# Altium schematic sheet ESP32_S3.SchDoc
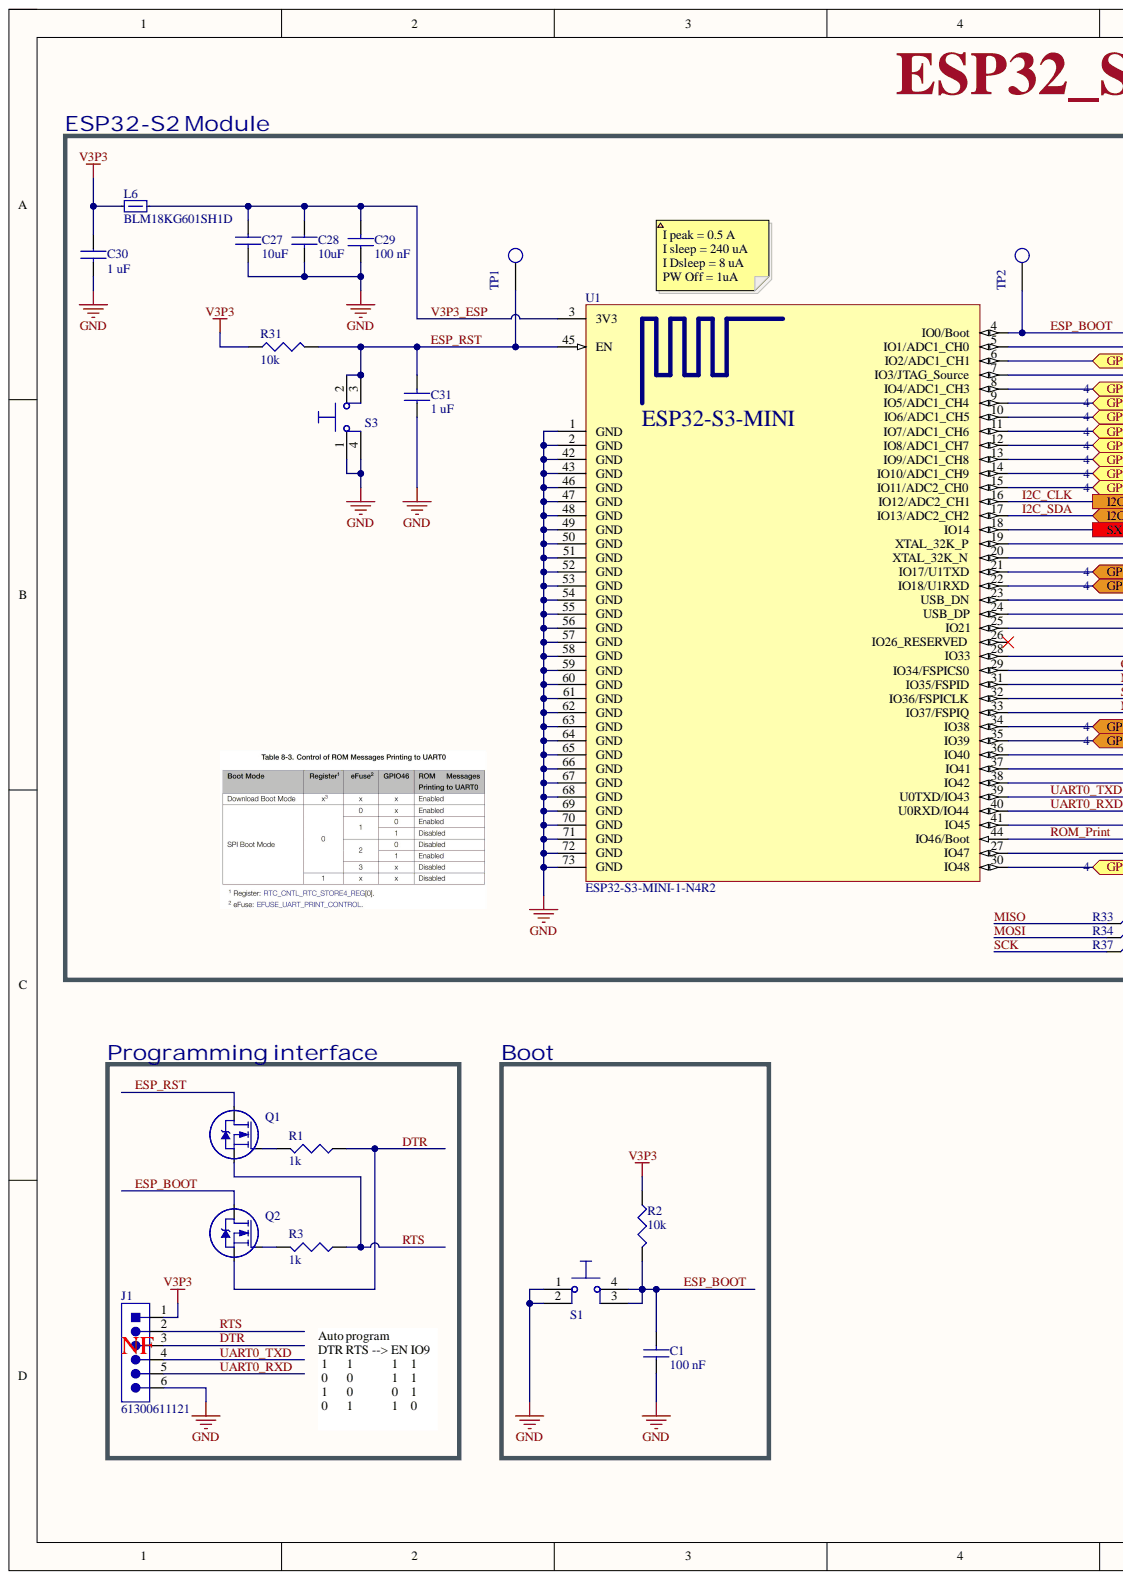
[diagram p0: ESP32_S3.SchDoc, left]
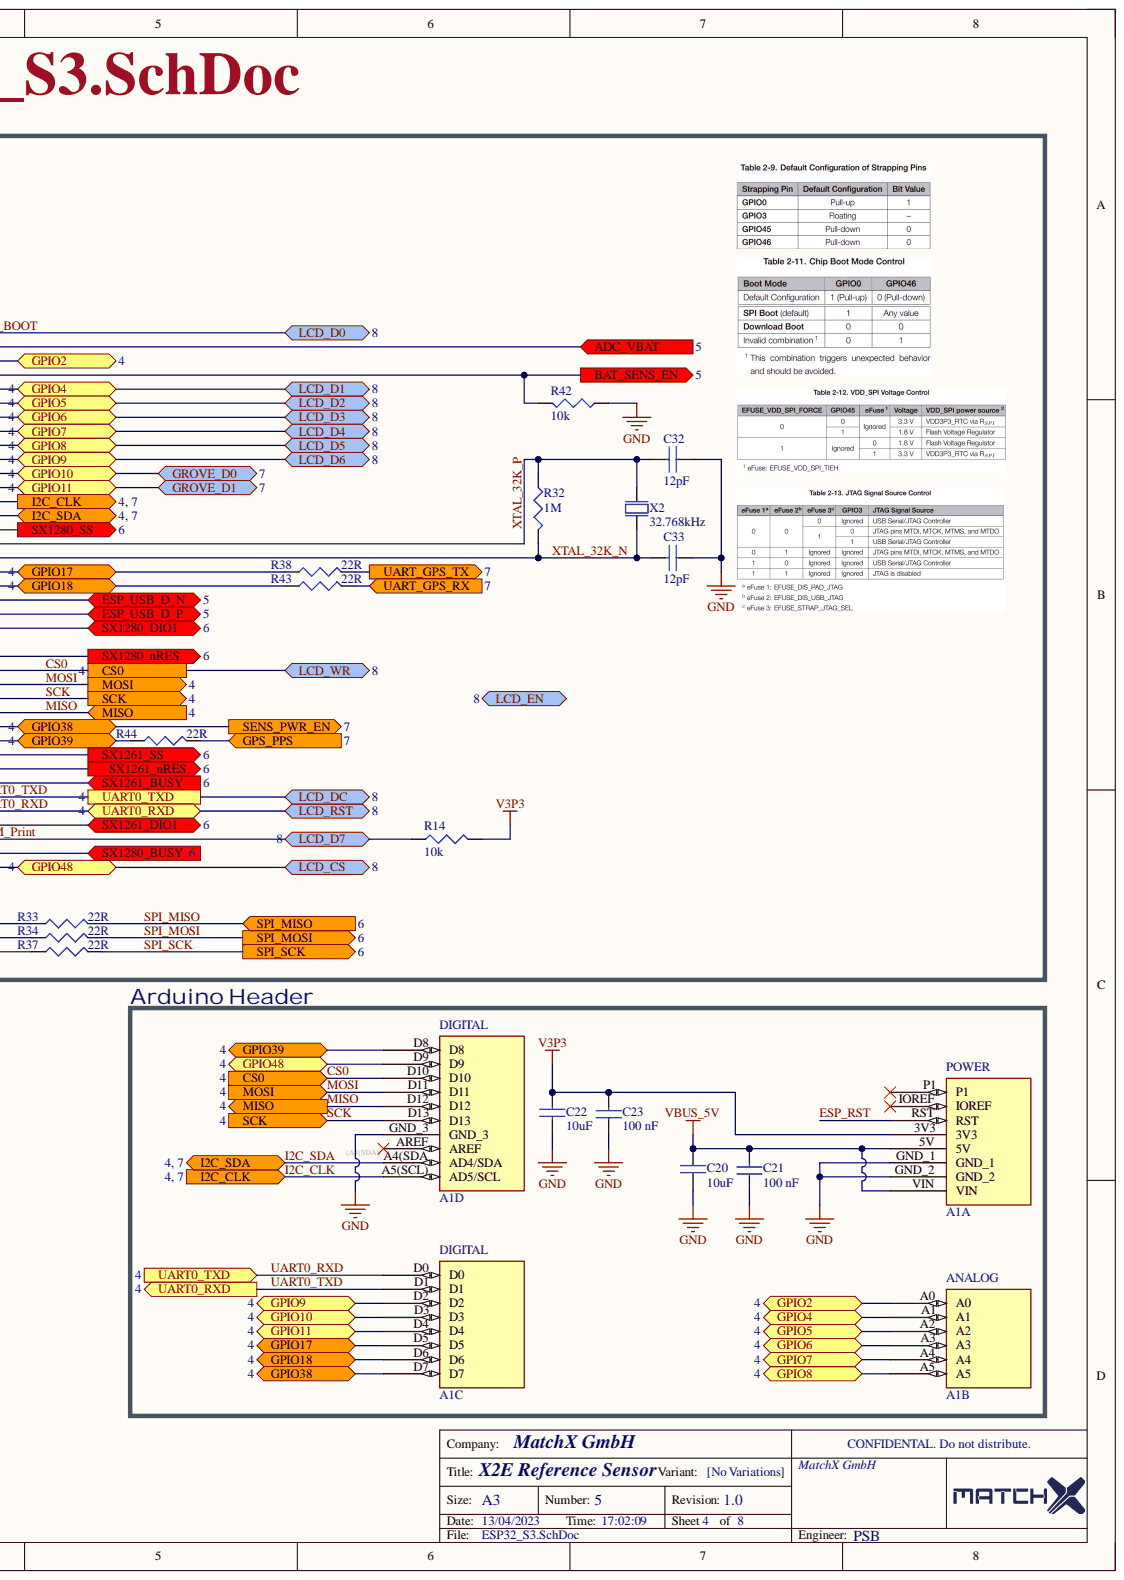
[diagram p1: ESP32_S3.SchDoc, right]

## Parts
A1  Arduino_Conn  [ARDUINO_TEMPLATE]  (value not drawn)  (parts A,B,C,D)
C1  100 nF  [C0603]
C20  10uF  [C0805]
C21  100 nF  [C0603]
C22  10uF  [C0805]
C23  100 nF  [C0603]
C27  10uF  [C0805]
C28  10uF  [C0805]
C29  100 nF  [C0603]
C30  1 uF  [C0603]
C31  1 uF  [C0603]
C32  12pF  [C0402]
C33  12pF  [C0402]
J1  61300611121  [WURTH_61300611121]
L6  BLM18KG601SH1D  [L0603]
Q1  DMN3023L-7  [SOT-23]  (value not drawn)
Q2  DMN3023L-7  [SOT-23]  (value not drawn)
R1  1k  [R0603]
R2  10k  [R0402]
R3  1k  [R0603]
R14  10k  [R0402]
R31  10k  [R0402]
R32  1M  [R0402]
R33  22R  [R0402]
R34  22R  [R0402]
R37  22R  [R0402]
R38  22R  [R0402]
R42  10k  [R0402]
R43  22R  [R0402]
R44  22R  [R0402]
S1  TS-1108A  [TS-1108A]  (value not drawn)
S3  TS-1108A  [TS-1108A]  (value not drawn)
TP1  TP  [TP_0R8]  (no BOM)
TP2  TP  [TP_0R8]  (no BOM)
U1  ESP32-S3-MINI-1-N4R2  [ESP32-S3-MINI-1-N4R2]
X2  32.768kHz  [XTAL_3215]

## Ports
ADC_VBAT, BAT_SENS_EN, CS0, ESP_USB_D_N, ESP_USB_D_P, GPIO2, GPIO4, GPIO5, GPIO6, GPIO7, GPIO8, GPIO9, GPIO10, GPIO11, GPIO17, GPIO18, GPIO38, GPIO39, GPIO48, GPS_PPS, GROVE_D0, GROVE_D1, I2C_CLK, I2C_SDA, LCD_CS, LCD_D0, LCD_D1, LCD_D2, LCD_D3, LCD_D4, LCD_D5, LCD_D6, LCD_D7, LCD_DC, LCD_EN, LCD_RST, LCD_WR, MISO, MOSI, SCK, SENS_PWR_EN, SPI_MISO, SPI_MOSI, SPI_SCK, SX1261_BUSY, SX1261_DIO1, SX1261_nRES, SX1261_SS, SX1280_BUSY, SX1280_DIO1, SX1280_nRES, SX1280_SS, UART0_RXD, UART0_TXD, UART_GPS_RX, UART_GPS_TX

## Nets
GND: A1.GND_1 A1.GND_2 A1.GND_3 C1.1 C20.2 C21.1 C22.2 C23.1 C27.2 C28.2 C29.1 C30.2 C31.1 C32.1 C33.1 J1.6 R42.1 S1.1 S1.2 S3.1 S3.4 U1.1(GND) U1.2(GND) U1.42(GND) U1.43(GND) U1.46(GND) U1.47(GND) U1.48(GND) U1.49(GND) U1.50(GND) U1.51(GND) U1.52(GND) U1.53(GND) U1.54(GND) U1.55(GND) U1.56(GND) U1.57(GND) U1.58(GND) U1.59(GND) U1.60(GND) U1.61(GND) U1.62(GND) U1.63(GND) U1.64(GND) U1.65(GND) U1.66(GND) U1.67(GND) U1.68(GND) U1.69(GND) U1.70(GND) U1.71(GND) U1.72(GND) U1.73(GND)
V3P3: A1.3V3 C22.1 C23.2 C30.1 J1.1 L6.1 R2.1 R14.2 R31.1
VBUS_5V: A1.5V A1.VIN C20.1 C21.2
ADC_VBAT: U1.5(IO1/ADC1_CH0) ; port ADC_VBAT
BAT_SENS_EN: R42.2 U1.7(IO3/JTAG_Source) ; port BAT_SENS_EN
CS0: A1.D10 U1.29(IO34/FSPICS0) ; port CS0, port LCD_WR
DTR: J1.3(NF) Q2.2 R1.2
ESP_BOOT: C1.2 Q2.3 R2.2 S1.3 S1.4 TP2.0 U1.4(IO0/Boot) ; port LCD_D0
ESP_RST: A1.RST C31.2 Q1.3 R31.2 S3.2 S3.3 TP1.0 U1.45(EN)
ESP_USB_D_N: U1.23(USB_DN) ; port ESP_USB_D_N
ESP_USB_D_P: U1.24(USB_DP) ; port ESP_USB_D_P
GPIO2: A1.A0 U1.6(IO2/ADC1_CH1) ; port GPIO2
GPIO4: A1.A1 U1.8(IO4/ADC1_CH3) ; port GPIO4, port LCD_D1
GPIO5: A1.A2 U1.9(IO5/ADC1_CH4) ; port GPIO5, port LCD_D2
GPIO6: A1.A3 U1.10(IO6/ADC1_CH5) ; port GPIO6, port LCD_D3
GPIO7: A1.A4 U1.11(IO7/ADC1_CH6) ; port GPIO7, port LCD_D4
GPIO8: A1.A5 U1.12(IO8/ADC1_CH7) ; port GPIO8, port LCD_D5
GPIO9: A1.D2 U1.13(IO9/ADC1_CH8) ; port GPIO9, port LCD_D6
GPIO10: A1.D3 U1.14(IO10/ADC1_CH9) ; port GPIO10, port GROVE_D0
GPIO11: A1.D4 U1.15(IO11/ADC2_CH0) ; port GPIO11, port GROVE_D1
GPIO17: A1.D5 R38.1 U1.21(IO17/U1TXD) ; port GPIO17
GPIO18: A1.D6 R43.1 U1.22(IO18/U1RXD) ; port GPIO18
GPIO38: A1.D7 U1.34(IO38) ; port GPIO38, port SENS_PWR_EN
GPIO39: A1.D8 R44.1 U1.35(IO39) ; port GPIO39
GPIO48: A1.D9 U1.30(IO48) ; port GPIO48, port LCD_CS
GPS_PPS: R44.2 ; port GPS_PPS
I2C_CLK: A1.A5(SCL) U1.16(IO12/ADC2_CH1) ; port I2C_CLK
I2C_SDA: A1.A4(SDA) U1.17(IO13/ADC2_CH2) ; port I2C_SDA
MISO: A1.D12 R33.1 U1.33(IO37/FSPIQ) ; port MISO
MOSI: A1.D11 R34.1 U1.31(IO35/FSPID) ; port MOSI
ROM_Print: R14.1 U1.44(IO46/Boot) ; port LCD_D7
RTS: J1.2 Q1.2 R3.2
SCK: A1.D13 R37.1 U1.32(IO36/FSPICLK) ; port SCK
SPI_MISO: R33.2 ; port SPI_MISO
SPI_MOSI: R34.2 ; port SPI_MOSI
SPI_SCK: R37.2 ; port SPI_SCK
SX1261_BUSY: U1.38(IO42) ; port SX1261_BUSY
SX1261_DIO1: U1.41(IO45) ; port SX1261_DIO1
SX1261_nRES: U1.37(IO41) ; port SX1261_nRES
SX1261_SS: U1.36(IO40) ; port SX1261_SS
SX1280_BUSY: U1.27(IO47) ; port SX1280_BUSY
SX1280_DIO1: U1.25(IO21) ; port SX1280_DIO1
SX1280_nRES: U1.28(IO33) ; port SX1280_nRES
SX1280_SS: U1.18(IO14) ; port SX1280_SS
UART0_RXD: A1.D0 A1.D1 J1.4 J1.5 U1.39(U0TXD/IO43) U1.40(U0RXD/IO44) ; port LCD_DC, port LCD_RST, port UART0_RXD, port UART0_TXD
UART_GPS_RX: R43.2 ; port UART_GPS_RX
UART_GPS_TX: R38.2 ; port UART_GPS_TX
V3P3_ESP: C27.1 C28.1 C29.2 L6.2 U1.3(3V3)
XTAL_32K_N: C33.2 R32.1 U1.20(XTAL_32K_N) X2.1
XTAL_32K_P: C32.2 R32.2 U1.19(XTAL_32K_P) X2.2
NetQ1_1: Q1.1 R1.1
NetQ2_1: Q2.1 R3.1
N00001: ; port LCD_EN

Not connected: A1 AREF IOREF P1; U1 26(IO26_RESERVED)

## Library data of the parts
A1 = Arduino_Conn: Arduino Template; MP: Arduino Uno Rev3; Availability: Not in stock; Check_prices: https://www.snapeda.com/parts/Arduino%20Uno%20Rev3/Arduino/view-part/?ref=eda; Component Kind: Standard; MF: Arduino; Package: Non-Standard Arduino; Price: None; SnapEDA_Link: https://www.snapeda.com/parts/Arduino%20Uno%20Rev3/Arduino/view-part/?ref=snap; Library Name: psblib.SCHLIB
C1 C21 C23 C29 = C0603-100nF_50V: CAP, CERM, 100 nF, 50V, +/- 10%, X7R, 0603; CompType: Capacitor; Category: Capacitors; Component Kind: Standard; MountType: SMT; Operating Temperature: -55°C ~ 125°C; Package / Case: 0603 (1608 Metric); RoHS: RoHS Compliant; Technology: Ceramic; Temperature Coefficient: X7R; Tolerance: 10%; Value: 100 nF; Voltage - Rated: 50V; Library Name: psblib.SCHLIB
C20 C22 C27 C28 = C0805-10uF_16V: CAP, CERM, 10uF, 16V, +/- 20%, X5R, 0805; CompType: Capacitor; Category: Capacitors; Component Kind: Standard; MountType: SMT; Operating Temperature: -55°C ~ 85°C; Package / Case: 0805 (2012 Metric); RoHS: RoHS Compliant; Technology: Ceramic; Temperature Coefficient: X5R; Tolerance: 20%; Value: 10uF; Voltage - Rated: 16 V; Library Name: psblib.SCHLIB
C30 C31 = C0603-1uF_25V: CAP, CERM, 1 uF, 25 V, +/- 10%, X7R, 0603; CompType: Capacitor; Voltage - Rated: 25 V; Category: Capacitors; Component Kind: Standard; MountType: SMT; Operating Temperature: -55°C ~ 125°C; Package / Case: 0603 (1608 Metric); RoHS: RoHS Compliant; Technology: Ceramic; Temperature Coefficient: X7R; Tolerance: 10%; Value: 1 uF; Library Name: psblib.SCHLIB
C32 C33 = C0402-12pF: CAP, CERM, 12pF, 16V, +/- 5%, C0G/NP0, 0402; CompType: Capacitor; Category: Capacitors; Component Kind: Standard; MountType: SMT; Operating Temperature: -55°C ~ 125°C; Package / Case: 0402; RoHS: RoHS Compliant; Technology: Ceramic; Temperature Coefficient: C0G/NP0; Tolerance: 5%; Value: 12pF; Voltage - Rated: 16 V; Library Name: psblib.SCHLIB
J1 = CON_61300611121: Header, 2.54 mm, 6x1, Gold, TH; Manufacturer: Wurth Electronics; Connector Type: Header, Unshrouded; Number of Positions: 6; Number of Positions Loaded: All; Pitch: 0.100" (2.54mm); Category: Connectors, Interconnects; Color: Black; Component Kind: Standard; ComponentLink1Description: http://www.farnell.com/cad/1737268.pdf; ComponentLink1URL: http://www.farnell.com/cad/1737268.pdf; Contact Finish: Gold; Contact Finish Thickness: -; Contact Mating Length: 0.236" (6.00mm); Contact Type: Male Pin; Family: Rectangular Connectors - Headers, Male Pins; Fastening Type: -; Features: -; Manufacturer Part Number: 61300611121; Mounting Type: Through Hole; Number of Rows: 1; Packaging: Bulk; Pricing 1: 1=0.25, 10=0.209, 25=0.174, 50=0.145, 100=0.132, 1000=0.12 (USD); Row Spacing: -; Series: WR-PHD; Stock 1: 0; Supplier 1: Digikey; Supplier Part Number 1: 732-5318-ND; Termination: Solder; Library Name: psblib.SCHLIB
L6 = BLM18KG601SH1D: Ferrite Beads 0603 600ohms 1.3A DCR=.15ohms; Manufacturer: Murata Electronics North America; DC Resistance (DCR) (Max): 150 mOhm; Filter Type: Power Line; Impedance @ Frequency: 600 Ohm @ 100MHz; Number of Lines: 1; Category: Filters; Component Kind: Standard; ComponentLink1Description: http://www.murata.com/en-global/products/productdata/8796737273886/QNFA9101.pdf; ComponentLink1URL: http://www.murata.com/en-global/products/productdata/8796737273886/QNFA9101.pdf; Current Rating (Max): 1.3A; Height (Max): 0.037" (0.95mm); Manufacturer Part Number: BLM18KG601SH1D; Mounting Type: Surface Mount; Operating Temperature: -55°C ~ 125°C; Package / Case: 0603 (1608 Metric); Packaging: Cut Tape (CT); Pricing 1: 1=0.11, 10=0.088, 50=0.058, 100=0.0502, 250=0.0396, 500=0.03344, 1000=0.02816 (USD); Ratings: AEC-Q200; RoHS: RoHS Compliant; Size / Dimension: 0.063" L x 0.032" W (1.60mm x 0.80mm); Stock 1: 5830; Supplier 1: Digi-Key; Supplier Part Number 1: 490-13259-1-ND; Library Name: psblib.SCHLIB
Q1 Q2 = NMOSFET_DMN3023L-7: MOSFETN-CH 30V 6.2A SOT23-3; Manufacturer: Diodes; .Checked PCB: OK M; Input Capacitance: 873pF; Max Power Dissipation: 900mW; Rds On Max: 25mR; Case/Package: TO-236-3; Category: MOSFETs; Component Kind: Standard; ComponentLink1Description: http://www.farnell.com/datasheets/2814401.pdf?src-supplier=Farnell; ComponentLink1URL: http://www.farnell.com/datasheets/2814401.pdf?src-supplier=Farnell; ComponentLink2Description: http://www.mouser.com/ds/2/115/DMN3023L-959533.pdf?src-supplier=Mouser; ComponentLink2URL: http://www.mouser.com/ds/2/115/DMN3023L-959533.pdf?src-supplier=Mouser; ComponentLink3Description: http://www.farnell.com/datasheets/2814401.pdf?src-supplier=Newark; ComponentLink3URL: http://www.farnell.com/datasheets/2814401.pdf?src-supplier=Newark; ComponentLink4Description: http://www.farnell.com/datasheets/2814401.pdf?src-supplier=Element14; ComponentLink4URL: http://www.farnell.com/datasheets/2814401.pdf?src-supplier=Element14; ComponentLink5Description: https://www.diodes.com/assets/Datasheets/DMN3023L.pdf?src-supplier=Digi-Key; ComponentLink5URL: https://www.diodes.com/assets/Datasheets/DMN3023L.pdf?src-supplier=Digi-Key; Continuous Drain Current (ID): 6.2A; Drain to Source Voltage (Vdss): 30V; Manufacturer Part Number: DMN3023L-7; Max Operating Temperature: 150°C; Min Operating Temperature: -55°C; Mount: Surface Mount; Packaging: Tape and Reel; Pricing 1: 1=0,41000, 10=0,33100, 100=0,22560, 500=0,16922, 1000=0,12692 (USD); RoHS: Yes; RoHS Compliant: Yes; Stock 1: 420813; Supplier 1: Digi-Key; Supplier Part Number 1: DMN3023L-7DICT-ND; .Checked SCH: OK M; Library Name: psblib.SCHLIB
R1 R3 = R0603-1k: RES, 1k, 1%, 0.063 W, 0603; .Checked PCB: OK RF; .Checked SCH: RF; Power (Watts): 0.063W, 1/16W; Category: Resistors; Component Kind: Standard; Composition: Thick Film; Features: -; Height: 0.016" (0.40mm); Number of Terminations: 2; Package / Case: 0603 [1608 Metric]; RoHS: RoHS Compliant; Size / Dimension: 0.063" L x 0.031" W (1.60mm x 0.80mm); Tolerance: ±1%; Value: 1k; Library Name: psblib.SCHLIB
R2 R14 R31 R42 = R0402-10k: RES, 10k, 1%, 1/16 W, 0402; .Checked PCB: OK RF; .Checked SCH: RF; Power (Watts): 0.063W, 1/16W; Category: Resistors; Component Kind: Standard; Composition: Thick Film; Features: -; Height: 0.016" (0.40mm); Number of Terminations: 2; Package / Case: 0402; RoHS: RoHS Compliant; Size / Dimension: 0.039" L x 0.020" W (1.00mm x 0.50mm); Tolerance: ±1%; Value: 10k; Library Name: psblib.SCHLIB
R32 = R0402-1M: RES, 1M, 1%, 1/16 W, 0402; .Checked PCB: OK RF; .Checked SCH: RF; Power (Watts): 0.063W, 1/16W; Category: Resistors; Component Kind: Standard; Composition: Thick Film; Features: -; Height: 0.016" (0.40mm); Number of Terminations: 2; Package / Case: 0402; RoHS: RoHS Compliant; Size / Dimension: 0.039" L x 0.020" W (1.00mm x 0.50mm); Tolerance: ±1%; Value: 1M; Library Name: psblib.SCHLIB
R33 R34 R37 R38 R43 R44 = R0402-22R: RES, 22R, 1%, 1/16 W, 0402; .Checked PCB: OK RF; .Checked SCH: RF; Power (Watts): 0.063W, 1/16W; Category: Resistors; Component Kind: Standard; Composition: Thick Film; Features: -; Height: 0.016" (0.40mm); Number of Terminations: 2; Package / Case: 0402; RoHS: RoHS Compliant; Size / Dimension: 0.039" L x 0.020" W (1.00mm x 0.50mm); Tolerance: ±1%; Value: 22R; Library Name: psblib.SCHLIB
S1 S3 = TS-1108A: Switch Tactile N.O. SPST Button 12VDC 50mA 5N SMD T/R; Manufacturer: ROPARTS; Component Kind: Standard; Manufacturer Part Number: TS-1108A; Pricing 1: 1=0,78000, 10=0,69500, 50=0,62700, 100=0,59800, 1000=0,48400, 10000=0,46500 (USD); RoHS: Yes; Stock 1: 3728; Library Name: psblib.SCHLIB
TP1 TP2 = TP: Test Point; Library Name: psblib.SCHLIB
U1 = ESP32-S3-MINI-1-N4R2: WLAN-BLE Module – 802.11 SMD Module, ESP32-S3FH4R2 with 4 MB Flash Die, 2MB PSRAM, PCB Antenna; Manufacturer: Espressif Systems; Component Kind: Standard; Manufacturer Part Number: ESP32-S3-MINI-1-N4R2; Library Name: psblib.SCHLIB
X2 = XTAL_2-pin_DPAF32768004_7pF: XTAL SMD 3215, 32.768kHz, 7pF, ±20ppm; Alternate Manufacturer: Epson Toyocom; Alternate PartNumber: FC-135 32.768KHZ ±20PPM,9.0PF; Manufacturer: Guangdong Dapu Telecom Technology; Manufacturer Part Number: DPAF32768004 3215 32.768k 9pF; Note: XTAL SMD 32.768kHz, 3215; Component Kind: Standard; Package / Case: 3215; Part Number: DPAF32768004 3215 32.768k 9pF; Pin Count: 2; Value: 32.768kHz; Library Name: psblib.SCHLIB
